# Altium schematic sheet Pag3_Front_end_adc_dac.SchDoc(Pag3_Front_end_adc_dac)
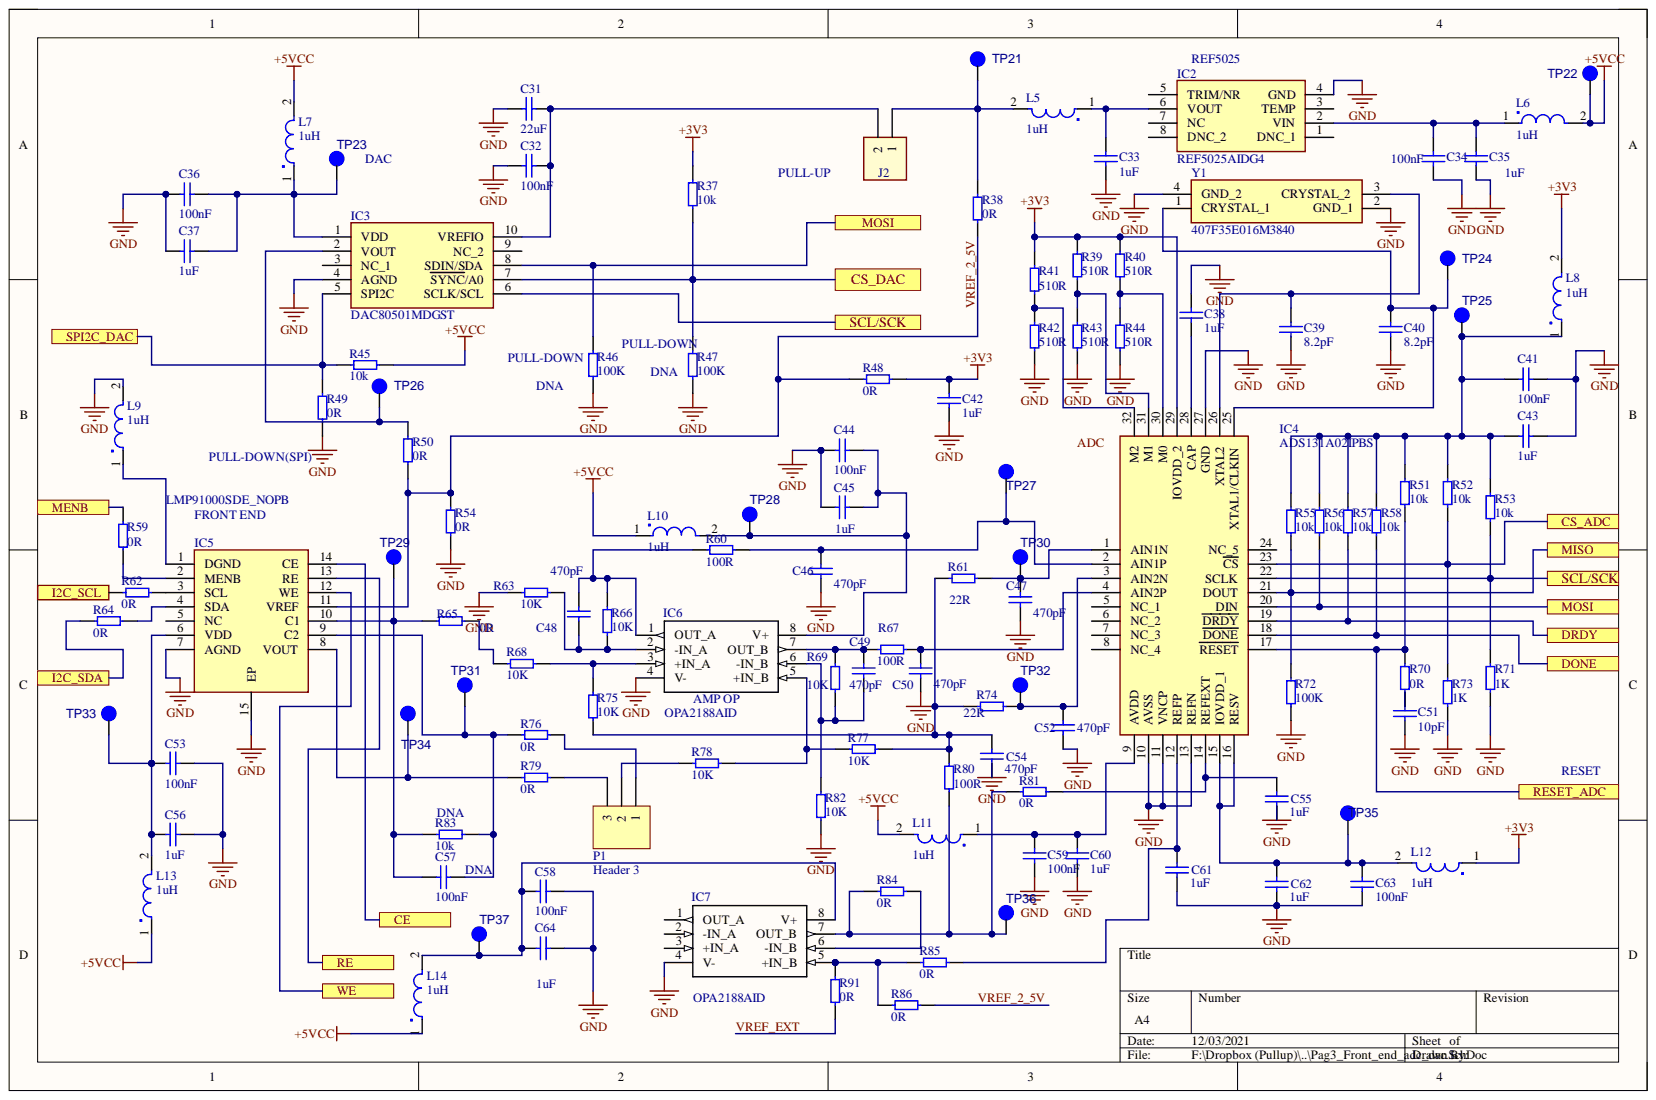
[diagram p0: Pag3_Front_end_adc_dac.SchDoc]

## Parts
C31  22uF  [0603]
C32  100nF  [0603]
C33  1uF  [0603]
C34  100nF  [0603]
C35  1uF  [0603]
C36  100nF  [0603]
C37  1uF  [0603]
C38  1uF  [0603]
C39  8.2pF  [0603]
C40  8.2pF  [0603]
C41  100nF  [0603]
C42  1uF  [0603]
C43  1uF  [0603]
C44  100nF  [0603]
C45  1uF  [0603]
C46  470pF  [0603]
C47  470pF  [0603]
C48  470pF  [0603]
C49  470pF  [0603]
C50  470pF  [0603]
C51  10pF  [0603]
C52  470pF  [0603]
C53  100nF  [0603]
C54  470pF  [0603]
C55  1uF  [0603]
C56  1uF  [0603]
C57  100nF  [0603]
C58  100nF  [0603]
C59  100nF  [0603]
C60  1uF  [0603]
C61  1uF  [0603]
C62  1uF  [0603]
C63  100nF  [0603]
C64  1uF  [0603]
IC2  REF5025AIDG4  [SOIC127P600X175-8N]
IC3  DAC80501MDGST  [SOP50P490X110-10N]
IC4  ADS131A02IPBS  [QFP50P700X700X120-32N]
IC5  LMP91000SDE_NOPB  [SON50P400X400X80-15N]
IC6  OPA2188AID  [SOIC127P600X175-8N]
IC7  OPA2188AID  [SOIC127P600X175-8N]
J2  Header 1X2  [HDR1X2-2]  (value not drawn)
L5  1uH  [SRP4012TA]
L6  1uH  [SRP4012TA]
L7  1uH  [SRP4012TA]
L8  1uH  [SRP4012TA]
L9  1uH  [SRP4012TA]
L10  1uH  [SRP4012TA]
L11  1uH  [SRP4012TA]
L12  1uH  [SRP4012TA]
L13  1uH  [SRP4012TA]
L14  1uH  [SRP4012TA]
P1  Header 3  [HDR1X3]
R37  10k  [0603]
R38  0R  [0603]
R39  510R  [0603]
R40  510R  [0603]
R41  510R  [0603]
R42  510R  [0603]
R43  510R  [0603]
R44  510R  [0603]
R45  10k  [0603]
R46  100K  [0603]
R47  100K  [0603]
R48  0R  [0603]
R49  0R  [0603]
R50  0R  [0603]
R51  10k  [0603]
R52  10k  [0603]
R53  10k  [0603]
R54  0R  [0603]
R55  10k  [0603]
R56  10k  [0603]
R57  10k  [0603]
R58  10k  [0603]
R59  0R  [0603]
R60  100R  [0603]
R61  22R  [0603]
R62  0R  [0603]
R63  10K  [0603]
R64  0R  [0603]
R65  0R  [0603]
R66  10K  [0603]
R67  100R  [0603]
R68  10K  [0603]
R69  10K  [0603]
R70  0R  [0603]
R71  1K  [0603]
R72  100K  [0603]
R73  1K  [0603]
R74  22R  [0603]
R75  10K  [0603]
R76  0R  [0603]
R77  10K  [0603]
R78  10K  [0603]
R79  0R  [0603]
R80  100R  [0603]
R81  0R  [0603]
R82  10K  [0603]
R83  10k  [0603]
R84  0R  [0603]
R85  0R  [0603]
R86  0R  [0603]
R91  0R  [0603]
TP21  =value  [TEST]
TP22  =value  [TEST]
TP23  =value  [TEST]
TP24  =value  [TEST]
TP25  =value  [TEST]
TP26  =value  [TEST]
TP27  =value  [TEST]
TP28  =value  [TEST]
TP29  =value  [TEST]
TP30  =value  [TEST]
TP31  =value  [TEST]
TP32  =value  [TEST]
TP33  =value  [TEST]
TP34  =value  [TEST]
TP35  =value  [TEST]
TP36  =value  [TEST]
TP37  =value  [TEST]
Y1  407F35E016M3840  [407F35E016M3840]

## Ports
CE, CS_ADC, CS_DAC, DONE, DRDY, I2C_SCL, I2C_SDA, MENB, MISO, MOSI, RE, RESET_ADC, SCL/SCK, SPI2C_DAC, WE

## Nets
+3V3: C42.2 IC4.29(IOVDD_2) L8.2 L12.1 R37.2 R39.2 R40.2 R41.2 R48.2
+5VCC: L6.2 L7.2 L10.1 L11.2 L13.1 L14.1 R45.1 TP22.1
GND: C31.1 C32.2 C33.1 C34.1 C35.1 C36.1 C37.2 C38.2 C39.1 C40.1 C41.2 C42.1 C43.1 C44.1 C45.2 C46.1 C47.1 C50.1 C51.1 C52.1 C53.2 C54.1 C55.1 C56.1 C58.2 C59.1 C60.1 C61.1 C62.1 C63.1 C64.1 IC2.4(GND) IC3.4(AGND) IC4.10(AVSS) IC4.11(VNCP) IC4.13(REFN) IC4.27(GND) IC5.7(AGND) IC5.15(EP) IC6.4(V-) IC7.4(V-) L9.2 R42.1 R43.1 R44.1 R46.1 R47.1 R49.1 R54.1 R63.1 R71.1 R72.1 R73.1 R82.1 Y1.2(GND_1) Y1.4(GND_2)
CE: IC5.14(CE) ; port CE
CS_ADC: IC4.23(CS) R52.1 R73.2 ; port CS_ADC
CS_DAC: IC3.7(SYNC/A0) R37.1 R47.2 ; port CS_DAC
DONE: IC4.18(DONE) R58.1 ; port DONE
DRDY: IC4.19(DRDY) R57.1 ; port DRDY
I2C_SCL: R62.2 ; port I2C_SCL
I2C_SDA: R64.2 ; port I2C_SDA
MENB: R59.2 ; port MENB
MISO: IC4.21(DOUT) R55.1 R72.2 ; port MISO
MOSI: IC3.8(SDIN/SDA) IC4.20(DIN) R46.2 R56.1 ; port MOSI
RE: IC5.13(RE) ; port RE
RESET_ADC: IC4.17(RESET) R51.1 R70.2 ; port RESET_ADC
SCL/SCK: IC3.6(SCLK/SCL) IC4.22(SCLK) R53.1 R71.2 ; port SCL/SCK
SPI2C_DAC: IC3.5(SPI2C) R45.2 R49.2 ; port SPI2C_DAC
VREF_2_5V: IC5.11(VREF) R38.1 R48.1 R50.1 R54.2 R86.1
VREF_EXT: R91.2
WE: IC5.12(WE) ; port WE
NetC31_2: C31.2 C32.1 IC3.10(VREFIO) J2.2
NetC33_2: C33.2 IC2.6(VOUT) L5.1
NetC34_2: C34.2 C35.2 IC2.2(VIN) L6.1
NetC36_2: C36.2 C37.1 IC3.1(VDD) L7.1 TP23.1
NetC38_1: C38.1 IC4.28(CAP)
NetC39_2: C39.2 IC4.26(XTAL2) Y1.3(CRYSTAL_2)
NetC40_2: C40.2 IC4.25(XTAL1/CLKIN) TP24.1 Y1.1(CRYSTAL_1)
NetC41_1: C41.1 C43.2 L8.1 R51.2 R52.2 R53.2 R55.2 R56.2 R57.2 R58.2 TP25.1
NetC44_2: C44.2 C45.1 IC6.8(V+) L10.2 TP28.1
NetC46_2: C46.2 IC4.2(AIN1P) R60.2 TP27.1
NetC47_2: C47.2 IC4.1(AIN1N) R61.2 TP30.1
NetC48_1: C48.1 IC6.2(-IN_A) R63.2 R66.1
NetC48_2: C48.2 IC6.1(OUT_A) R60.1 R66.2
NetC49_1: C49.1 IC6.6(-IN_B) R69.1 R82.2
NetC49_2: C49.2 IC6.7(OUT_B) R67.1 R69.2
NetC50_2: C50.2 IC4.4(AIN2P) R67.2
NetC51_2: C51.2 R70.1
NetC52_2: C52.2 IC4.3(AIN2N) R74.2 TP32.1
NetC53_1: C53.1 C56.2 IC5.6(VDD) L13.2 TP33.1
NetC54_2: C54.2 R61.1 R74.1 R75.1 R77.2 R80.2
NetC55_2: C55.2 IC4.14(REFEXT) R81.1
NetC57_1: C57.1 IC5.10(C1) R65.2 R83.2 TP29.1
NetC57_2: C57.2 IC5.9(C2) R76.2 R83.1 TP31.1
NetC58_1: C58.1 C64.2 IC7.8(V+) L14.2 TP37.1
NetC59_2: C59.2 C60.2 IC4.9(AVDD) L11.1
NetC61_2: C61.2 IC4.12(REFP) R85.1
NetC62_2: C62.2 C63.2 IC4.15(IOVDD_1) IC4.16(RESV) L12.2 TP35.1
NetIC3_2: IC3.2(VOUT) R50.2 TP26.1
NetIC4_30: IC4.30(M0) R40.1 R44.2
NetIC4_31: IC4.31(M1) R39.1 R43.2
NetIC4_32: IC4.32(M2) R41.1 R42.2
NetIC5_1: IC5.1(DGND) L9.1
NetIC5_2: IC5.2(MENB) R59.1
NetIC5_3: IC5.3(SCL) R62.1
NetIC5_4: IC5.4(SDA) R64.1
NetIC5_8: IC5.8(VOUT) R79.2 TP34.1
NetIC6_3: IC6.3(+IN_A) R68.2 R75.2
NetIC6_5: IC6.5(+IN_B) R77.1 R78.2
NetIC7_5: IC7.5(+IN_B) R85.2 R86.2 R91.1
NetIC7_6: IC7.6(-IN_B) R84.1
NetIC7_7: IC7.7(OUT_B) R80.1 R81.2 R84.2 TP36.1
NetJ2_1: J2.1 L5.2 R38.2 TP21.1
NetP1_1: P1.1 R76.1
NetP1_2: P1.2 R78.1
NetP1_3: P1.3 R79.1
NetR65_1: R65.1 R68.1
ADC:

Not connected: IC2 1(DNC_1) 3(TEMP) 5(TRIM/NR) 7(NC) 8(DNC_2); IC3 3(NC_1) 9(NC_2); IC4 5(NC_1) 6(NC_2) 7(NC_3) 8(NC_4) 24(NC_5); IC5 5(NC); IC7 1(OUT_A) 2(-IN_A) 3(+IN_A)

## Library data of the parts
C31 = (no symbol name): Manufacturer: Murata; MPN: GRM188R61A106KE69J; PCBA: Assembly; Value: 10uF; Component Kind: Standard; Datasheet: http://www.mouser.com/ds/2/281/c02e-2905.pdf; Supplier 1: Mouser; Supplier 1 Link: http://www.mouser.com/ProductDetail/Murata-Electronics/GRM188R61A106KE69J/?qs=sGAEpiMZZMs0AnBnWHyRQAsAWwhBCY7bp3pFrpMtukFBX6VOqeHlKw%3d%3d; Supplier 1 Part Number: 81-GRM188R61A106KE9J; Library Name: potenciostato.SCHLIB
C32 C34 C36 C39-C41 C44 C51 C53 C57-C59 C63 = (no symbol name): Capacitor Ceramic 100nF 10% 16V 0603 (1608 Metric); Manufacturer: Kemet; MPN: C0603C104K4RACTU; Alternate: AA; CPqD PN: Pullup_Cap_100nF_0603_CAP; Engineering Change Status Code: Approved; Extra Info: - 55 C to + 125 C; LeadTime: 12 wks; Model_File: CAP; PCB INFO: Assembly; Temperature Coefficient: X7R; Complement Value: 16V; Component Kind: Standard; Component Type: Standard; Package: 0603 (1608 Metric); Price: 0,012; RoHS: SIM; Size / Dimension: 0.8 mm W x 1.6 mm L x 0.8 mm H; SVN_Link Data Sheet: http://svn.cpqd.com.br/DRT/biblio_comp/tags/datasheets/capacitor/kemet.pdf; Tolerance: 10%; Type: Capacitor Ceramic; Value: 100nF; Web_Link Data Sheet: http://www.kemet.com/kemet/web/homepage/kechome.nsf/vapubfiles/KEM_C1002_X7R_SMD.pdf/$file/KEM_C1002_X7R_SMD.pdf; Library Name: potenciostato.SCHLIB
C33 C35 C37 C38 C42 C43 C45 C55 C56 C60-C62 C64 = (no symbol name): Manufacturer: Samsung Electro-Mechanics; MPN: CL10A105KA8NNNC; PCBA: Assembly; Component Kind: Standard; Datasheet: https://www.mouser.com/datasheet/2/585/MLCC-1837944.pdf; Supplier 1: Mouser; Supplier 1 Link: https://www.mouser.com/ProductDetail/Samsung-Electro-Mechanics/CL10A105KA8NNNC?qs=hqM3L16%252BxldY%252BnK4KzDJVg%3D%3D; Supplier 1 Part Number: 187-CL10A105KA8NNNC; Value: 1uF; Library Name: potenciostato.SCHLIB
C46-C50 C52 C54 = (no symbol name): Manufacturer: KEMET; MPN: C0603C104M4RACAUTO; PCBA: Assembly; Value: 100nF; Component Kind: Standard; Datasheet: https://www.mouser.com/datasheet/2/212/KEM_C1023_X7R_AUTO_SMD-1093309.pdf; Supplier 1: Mouser; Supplier 1 Link: https://www.mouser.com/ProductDetail/KEMET/C0603C104M4RACAUTO?qs=sSYV1F9c5cFMyVgVMlPozw%3D%3D; Supplier 1 Part Number: 80-C0603C104M4RAUTO; Library Name: potenciostato.SCHLIB
IC2 = REF5025AIDG4: Integrated Circuit; Manufacturer_Name: Texas Instruments; Arrow Part Number: REF5025AIDG4; Arrow Price/Stock: https://www.arrow.com/en/products/ref5025aidg4/texas-instruments; Component Kind: Standard; Datasheet Link: https://www.ti.com/lit/ds/symlink/ref5020.pdf?HQS=TI-null-null-digikeymode-df-pf-null-wwe&ts=1600155491186; Height: 1.75mm; Library Name: ref5025.SchLib; Manufacturer_Part_Number: REF5025AIDG4; Mouser Part Number: 595-REF5025AIDG4; Mouser Price/Stock: https://www.mouser.co.uk/ProductDetail/Texas-Instruments/REF5025AIDG4?qs=EkVHNy6q9HOCTPcwZT2XxA%3D%3D
IC3 = DAC80501MDGST: Integrated Circuit; Manufacturer_Name: Texas Instruments; Arrow Part Number: DAC80501MDGST; Arrow Price/Stock: https://www.arrow.com/en/products/dac80501mdgst/texas-instruments?region=nac; Component Kind: Standard; Datasheet Link: https://www.ti.com/lit/gpn/DAC80501; Height: 1.1mm; Library Name: dac80501.SchLib; Manufacturer_Part_Number: DAC80501MDGST; Mouser Part Number: 595-DAC80501MDGST; Mouser Price/Stock: https://www.mouser.co.uk/ProductDetail/Texas-Instruments/DAC80501MDGST?qs=%252B6g0mu59x7I7TnYkBjkntw%3D%3D
IC4 = ADS131A02IPBS: Integrated Circuit; Manufacturer_Name: Texas Instruments; Arrow Part Number: ADS131A02IPBS; Arrow Price/Stock: https://www.arrow.com/en/products/ads131a02ipbs/texas-instruments; Component Kind: Standard; Datasheet Link: http://www.ti.com/lit/gpn/ADS131A02; Height: 1.2mm; Library Name: ADS131A02.SchLib; Manufacturer_Part_Number: ADS131A02IPBS; Mouser Part Number: 595-ADS131A02IPBS; Mouser Price/Stock: https://www.mouser.co.uk/ProductDetail/Texas-Instruments/ADS131A02IPBS/?qs=LuYMPh7GGMTfdsw07NqhcQ%3D%3D
IC5 = LMP91000SDE_NOPB: Integrated Circuit; Manufacturer_Name: Texas Instruments; Manufacturer_Part_Number: LMP91000SDE/NOPB; Arrow Part Number: LMP91000SDE/NOPB; Arrow Price/Stock: https://www.arrow.com/en/products/lmp91000sdenopb/texas-instruments; Component Kind: Standard; Datasheet Link: http://www.ti.com/lit/ds/symlink/lmp91000.pdf; Height: 0.8mm; Library Name: LMP91000.SchLib; Mouser Part Number: 926-LMP91000SDE/NOPB; Mouser Price/Stock: https://www.mouser.co.uk/ProductDetail/Texas-Instruments/LMP91000SDE-NOPB?qs=7lkVKPoqpbaxskRUH9aH7Q%3D%3D
IC6 IC7 = OPA2188AID: Integrated Circuit; Manufacturer_Name: Texas Instruments; Arrow Part Number: OPA2188AID; Arrow Price/Stock: https://www.arrow.com/en/products/opa2188aid/texas-instruments?region=nac; Component Kind: Standard; Datasheet Link: http://www.ti.com/lit/ds/symlink/opa2188.pdf; Height: 1.75mm; Library Name: OPA2188.SchLib; Manufacturer_Part_Number: OPA2188AID; Mouser Part Number: 595-OPA2188AID; Mouser Price/Stock: https://www.mouser.co.uk/ProductDetail/Texas-Instruments/OPA2188AID?qs=r0FtUjCKcrRx64plk1OH2w%3D%3D
J2 = (no symbol name): PCBA: DNA; Component Kind: Standard; MPN: Header; Value: Header 1X2; Library Name: potenciostato.SCHLIB
L5-L14 = (no symbol name): Manufacturer: Bourns; MPN: SRP4012TA-1R0M; PCBA: Assembly; Component Kind: Standard; Datasheet: https://www.mouser.com/datasheet/2/54/rp4012ta-1391620.pdf; Supplier 1: Mouser; Supplier 1 Link: https://www.mouser.com/ProductDetail/Bourns/SRP4012TA-1R0M?qs=%2Fha2pyFaduhnZC9XlWRPjS9IbC2g%252Bs6L7AFI%2FwucAauXoSPPWYzFLg%3D%3D; Supplier 1 Part Number: 652-SRP4012TA-1R0M; Value: 1uH; Library Name: potenciostato.SCHLIB
P1 = Header 3: Header, 3-Pin; Component Kind: Standard; LatestRevisionDate: 17-Jul-2002; LatestRevisionNote: Re-released for DXP Platform.; Library Name: Miscellaneous Connectors.IntLib; Signal Integrity: Connector; Publisher: Altium Limited
R37 R38 R45 R48 R51-R53 R55-R58 R83 = (no symbol name): Manufacturer: Yageo; MPN: RC0603JR-07100KL; PCBA: Assembly; Value: 100K; Component Kind: Standard; Datasheet: https://www.mouser.com/datasheet/2/447/PYu-RC_Group_51_RoHS_L_10-1527934.pdf; Supplier 1: Mouser; Supplier 1 Link: https://www.mouser.com/ProductDetail/Yageo/RC0603JR-07100KL?qs=sGAEpiMZZMu61qfTUdNhG5eFuApKbqVdI3BI7%252bGKRK0%3d; Supplier 1 Part Number: 603-RC0603JR-07100KL; Library Name: potenciostato.SCHLIB
R39-R44 = (no symbol name): Manufacturer: Yageo; MPN: RC0603JR-070RL; PCBA: Assembly; Value: 0R; Component Kind: Standard; Datasheet: https://www.mouser.com/datasheet/2/447/PYu-RC_Group_51_RoHS_L_10-1527934.pdf; Supplier 1: Mouser; Supplier 1 Link: https://www.mouser.com/ProductDetail/Yageo/RC0603JR-070RL?qs=sGAEpiMZZMu61qfTUdNhG5eFuApKbqVdMPNPP1VDJp4%3d; Supplier 1 Part Number: 603-RC0603JR-070RL; Library Name: potenciostato.SCHLIB
R46 R47 R72 = (no symbol name): Manufacturer: Yageo; MPN: RC0603JR-07100KL; PCBA: Assembly; Component Kind: Standard; Datasheet: https://www.mouser.com/datasheet/2/447/PYu-RC_Group_51_RoHS_L_10-1527934.pdf; Supplier 1: Mouser; Supplier 1 Link: https://www.mouser.com/ProductDetail/Yageo/RC0603JR-07100KL?qs=sGAEpiMZZMu61qfTUdNhG5eFuApKbqVdI3BI7%252bGKRK0%3d; Supplier 1 Part Number: 603-RC0603JR-07100KL; Value: 100K; Library Name: potenciostato.SCHLIB
R49 R50 R54 R59 R62 R64 R65 R70 R76 R79 R81 R84-R86 R91 = (no symbol name): Manufacturer: Yageo; MPN: RC0603JR-070RL; PCBA: Assembly; Component Kind: Standard; Datasheet: https://www.mouser.com/datasheet/2/447/PYu-RC_Group_51_RoHS_L_10-1527934.pdf; Supplier 1: Mouser; Supplier 1 Link: https://www.mouser.com/ProductDetail/Yageo/RC0603JR-070RL?qs=sGAEpiMZZMu61qfTUdNhG5eFuApKbqVdMPNPP1VDJp4%3d; Supplier 1 Part Number: 603-RC0603JR-070RL; Value: 0R; Library Name: potenciostato.SCHLIB
R60 R63 R66-R69 R75 R77 R78 R80 R82 = (no symbol name): Manufacturer: Yageo; MPN: RC1218JK-070RL; PCBA: Assembly; Value: 0R; Component Kind: Standard; Datasheet: http://www.mouser.com/ds/2/447/PYu-RC1218_51_RoHS_L_(3)-2499.pdf; Supplier 1: Mouser; Supplier 1 Link: http://www.mouser.com/ProductDetail/Yageo/RC1218JK-070RL/?qs=sGAEpiMZZMvdGkrng054t%2fjcxOrcSHNr2Q9lXz27jXA%3d; Supplier 1 Part Number: 603-RC1218JK-070RL; Library Name: potenciostato.SCHLIB
R61 R74 = Res_3: MPN: CR0603-JW-151ELF; PCBA: Assembly; Value: 150R; Component Kind: Standard; Supplier: Mouser; Supplier Link: https://www.mouser.com/ProductDetail/Bourns/CR0603-JW-151ELF/?qs=sGAEpiMZZMvdGkrng054t%252BUcv6lYODj35J3%2FZubT2cc%3D; Supplier PN: 652-CR0603-JW-151ELF; Library Name: potenciostato.SCHLIB
R71 R73 = Res: Manufacturer: Yageo; MPN: RT0603FRE0722RL; PCBA: Assembly; Value: 22R; Component Kind: Standard; Datasheet: https://www.mouser.com/datasheet/2/447/PYu-RT_1-to-0.01_RoHS_L_9-1222720.pdf; Supplier 1: Mouser; Supplier 1 Link: https://www.mouser.com/ProductDetail/Yageo/RT0603FRE0722RL?qs=sGAEpiMZZMvdGkrng054t%252BKCHBXLTLydHS5lkk1PoYI%3D; Supplier 1 Part Number: 603-RT0603FRE0722RL; Library Name: potenciostato.SCHLIB
TP21-TP37 = TESTPOINT: Component Kind: Standard; Library Name: potenciostato.SCHLIB
Y1 = 407F35E016M3840: Crystal or Oscillator; Manufacturer_Name: CTS; Component Kind: Standard; Datasheet Link: http://www.ctscorp.com/wp-content/uploads/407.pdf; Height: 1.3mm; Manufacturer_Part_Number: 407F35E016M3840
